# Altium schematic sheet Main_Board.SchDoc
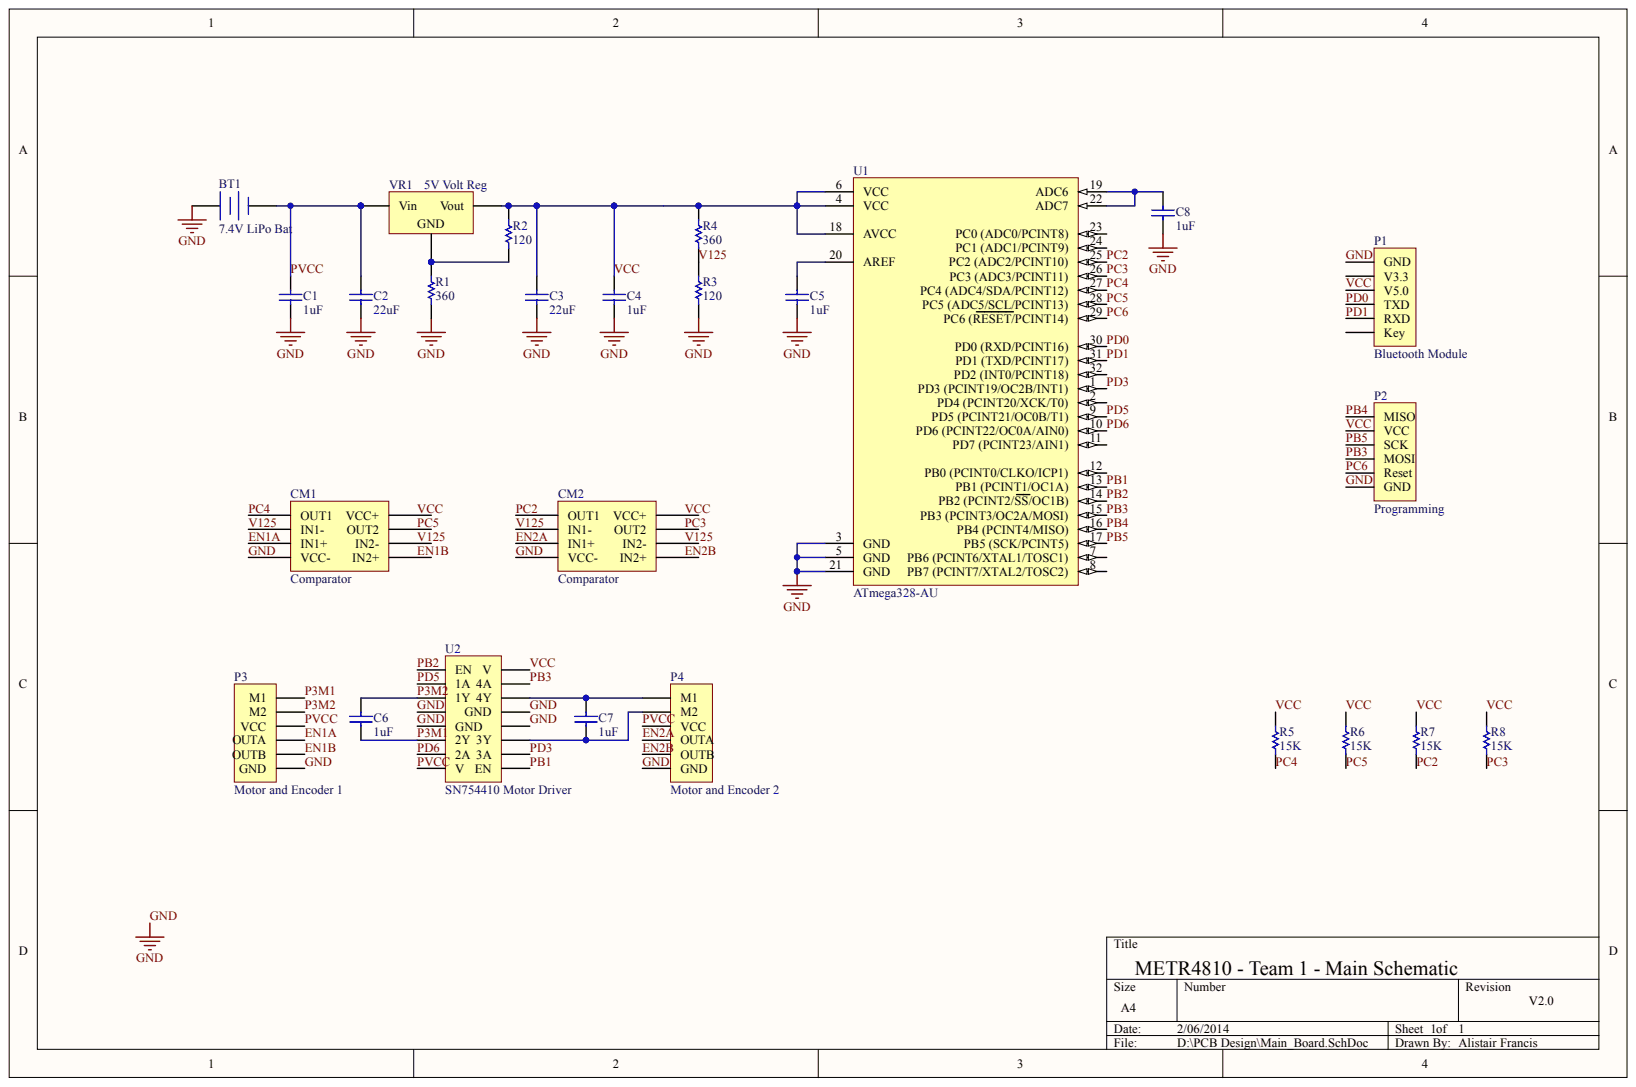
[diagram p0: Main_Board.SchDoc]

## Parts
BT1  7.4V LiPo Bat
C1  value 1uF
C2  value 22uF
C3  value 22uF
C4  value 1uF
C5  value 1uF
C6  value 1uF
C7  value 1uF
C8  value 1uF
CM1  Comparator
CM2  Comparator
P1  Bluetooth Module
P2  Programming
P3  Motor and Encoder 1
P4  Motor and Encoder 2
R1  value 360
R2  value 120
R3  value 120
R4  value 360
R5  value 15K
R6  value 15K
R7  value 15K
R8  value 15K
U1  ATmega328-AU
U2  SN754410 Motor Driver
VR1  5V Volt Reg

## Nets
EN1A: CM1.3(IN1+) P3.3
EN1B: P3.2
EN2A: CM2.3(IN1+) P4.4(OUTA)
EN2B: P4.5(OUTB)
GND: BT1.1 C1.2 C2.2 C3.2 C4.2 C5.2 C8.2 CM1.4(VCC-) CM2.4(VCC-) P1.1(GND) P2.6(GND) P3.1(GND) P4.6(GND) R1.1 R3.2 U1.3(GND) U1.5(GND) U1.21(GND) U2.4 U2.5(GND) U2.12(GND) U2.13
P3M1: C6.2 P3.6(M1) U2.6(2Y)
P3M2: C6.1 P3.5(M2) U2.3(1Y)
PB1: U1.13(PB1_(PCINT1/OC1A)) U2.9(EN)
PB2: U1.14(PB2_(PCINT2/SS/OC1B)) U2.1(EN)
PB3: P2.4(MOSI) U1.15(PB3_(PCINT3/OC2A/MOSI)) U2.15(4A)
PB4: P2.1(MISO) U1.16(PB4_(PCINT4/MISO))
PB5: P2.3(SCK) U1.17(PB5_(SCK/PCINT5))
PC2: CM2.1(OUT1) R7.2 U1.25
PC3: R8.2 U1.26(PC3_(ADC3/PCINT11))
PC4: CM1.1(OUT1) R5.2 U1.27(PC4_(ADC4/SDA/PCINT12))
PC5: R6.2 U1.28(PC5_(ADC5/SCL/PCINT13))
PC6: P2.5(Reset) U1.29(PC6_(RESET/PCINT14))
PD0: P1.4(TXD) U1.30(PD0_(RXD/PCINT16))
PD1: P1.5(RXD) U1.31(PD1_(TXD/PCINT17))
PD3: U1.1(PD3_(PCINT19/OC2B/INT1)) U2.10(3A)
PD5: U1.9(PD5_(PCINT21/OC0B/T1)) U2.2(1A)
PD6: U1.10(PD6_(PCINT22/OC0A/AIN0)) U2.7(2A)
PVCC: BT1.2 C1.1 C2.1 P3.4(VCC) P4.3(VCC) U2.8(V) VR1.1
V125: CM1.2(IN1-) CM2.2(IN1-) R3.1 R4.1
VCC: C3.1 C4.1 P1.3(V5.0) P2.2(VCC) R2.1 R4.2 R5.1 R6.1 R7.1 R8.1 U1.4(VCC) U1.6(VCC) U1.18(AVCC) U2.16(V) VR1.2
NetC5_1: C5.1 U1.20(AREF)
NetC7_1: C7.1 P4.1(M1) U2.14(4Y)
NetC7_2: C7.2 P4.2(M2) U2.11(3Y)
NetC8_1: C8.1 U1.19(ADC6) U1.22(ADC7)
NetR1_2: R1.2 R2.2 VR1.3

Not connected: CM1 5(IN2+) 6(IN2-) 7(OUT2) 8(VCC+); CM2 5(IN2+) 6(IN2-) 7(OUT2) 8(VCC+); P1 2(V3.3) 6(Key); U1 2(PD4_(PCINT20/XCK/T0)) 7 8 11(PD7_(PCINT23/AIN1)) 12(PB0_(PCINT0/CLKO/ICP1)) 23 24(PC1_(ADC1/PCINT9)) 32(PD2_(INT0/PCINT18))

## Library data of the parts
BT1 C1-C8 CM1 CM2 P1-P4 R1-R8 U1 U2 VR1 = (no symbol name)
  BT1: Published: 24-Mar-1999; LatestRevisionDate: 17-Jul-2002; LatestRevisionNote: Re-released for DXP Platform.; PackageReference: BAT-2; PackageDescription: Battery; 2 Leads
  C1-C8: Published: 8-Jun-2000; LatestRevisionDate: 17-Jul-2002; LatestRevisionNote: Re-released for DXP Platform.; PackageReference: RAD-0.3
  P1-P4 U2: LatestRevisionDate: 17-Jul-2002; LatestRevisionNote: Re-released for DXP Platform.
  R1-R8: Published: 8-Jun-2000; LatestRevisionDate: 17-Jul-2002; LatestRevisionNote: Re-released for DXP Platform.; PackageReference: AXIAL-0.3
  U1: Published: 1-Apr-2010; DatasheetVersion: revA, Jan-2010; PackageVersion: revB, Oct-2001; PackageReference: 32A; PackageDescription: 32-Lead, 7x7x1.0 mm Body, 0.8mm Lead Pitch, Thin Profile Plastic Quad Flat Package (TQFP); Supplier 1: Digi-Key; Supplier Part Number 1: ATMEGA328-AU-ND; Supplier 2: Mouser; Supplier Part Number 2: 556-ATMEGA328-AU; Code_JEDEC: MS-026-ABA; ComponentLink1Description: Manufacturer Link; ComponentLink1URL: http://www.atmel.com/products/avr/default.asp?; ComponentLink2Description: ATmega48A/48PA/88A/88PA/168A/168PA/328/328P Datasheet; ComponentLink2URL: http://www.atmel.com/dyn/resources/prod_documents/8271.pdf; ComponentLink3Description: ATmega48A/48PA/88A/88PA/168A/168PA/328/328P Summary; ComponentLink3URL: http://www.atmel.com/dyn/resources/prod_documents/8271S.pdf; ComponentLink4Description: Atmel.com Home; ComponentLink4URL: http://www.atmel.com
  VR1: Published: 24-Mar-1999; LatestRevisionDate: 29-May-2009; LatestRevisionNote: IPC-7351 Footprint Added.; PackageReference: D2PAK; PackageDescription: 3-Pin Transistor Outline Package 2.54 mm Pitch, 15.1 mm Lead Span
  BT1 C1-C8 P1-P4 R1-R8 U1 U2 VR1: Publisher: Altium Limited
